# Altium schematic sheet SAM3X_MCU2.SchDoc
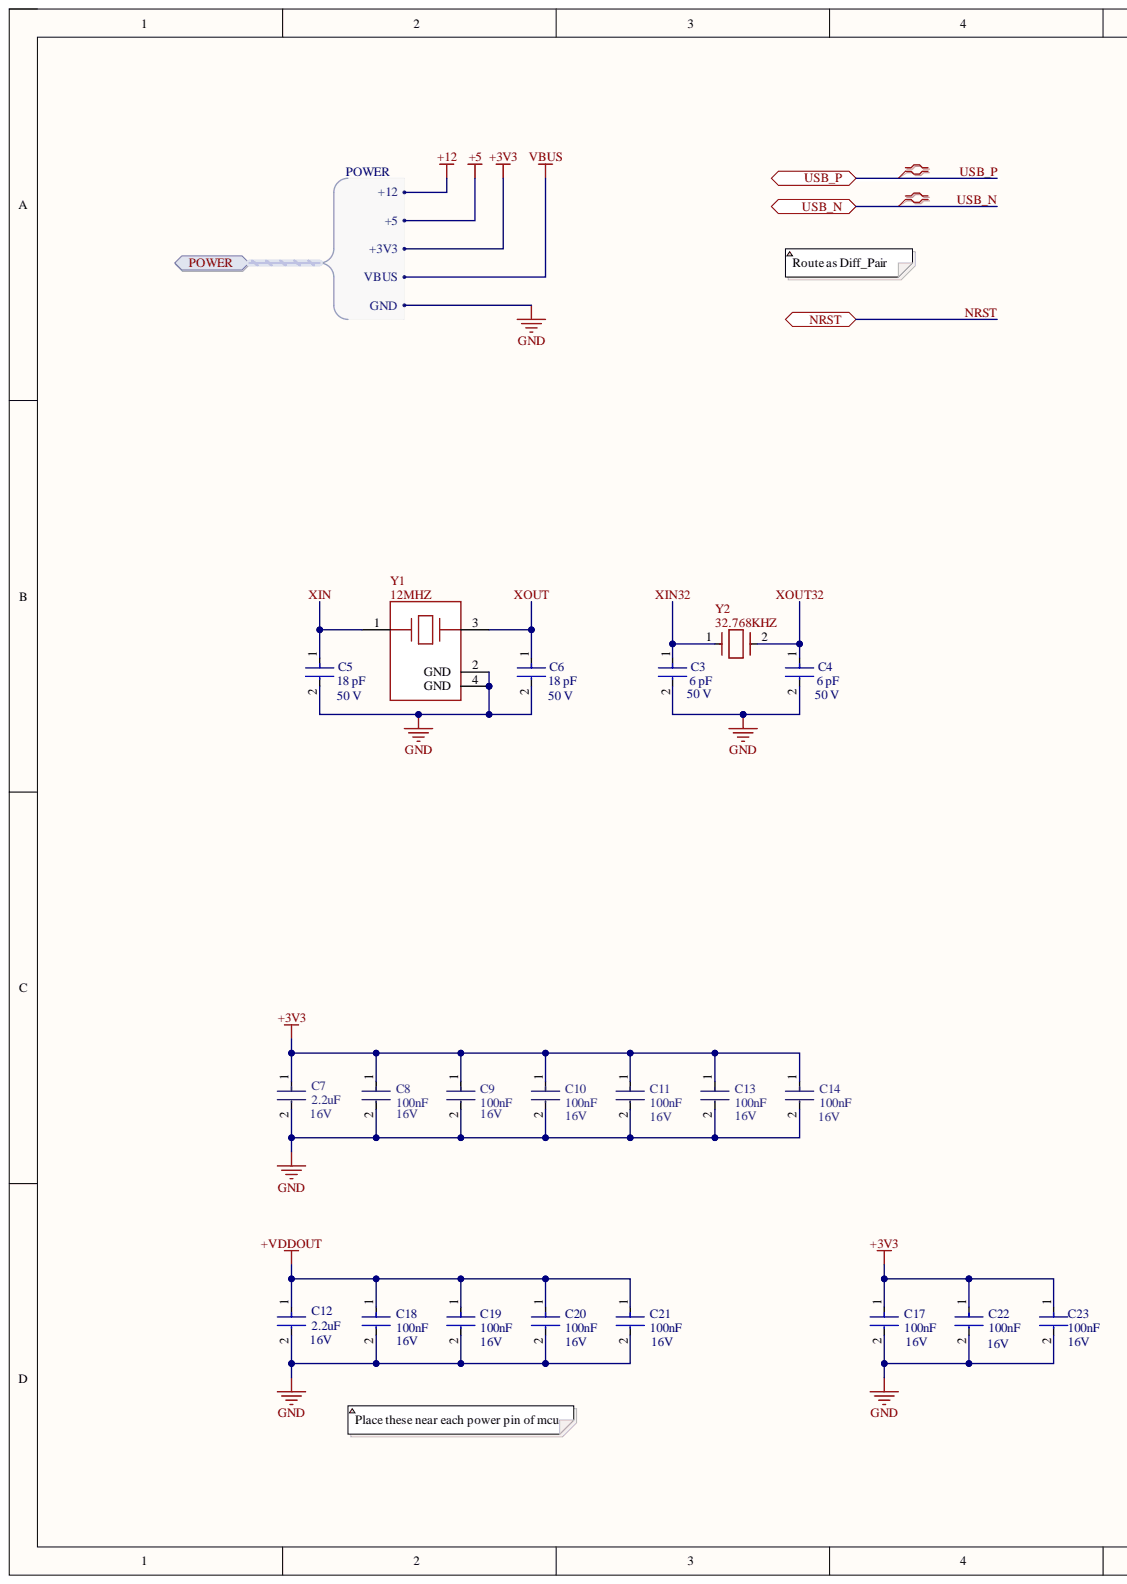
[diagram p0: SAM3X_MCU2.SchDoc, left]
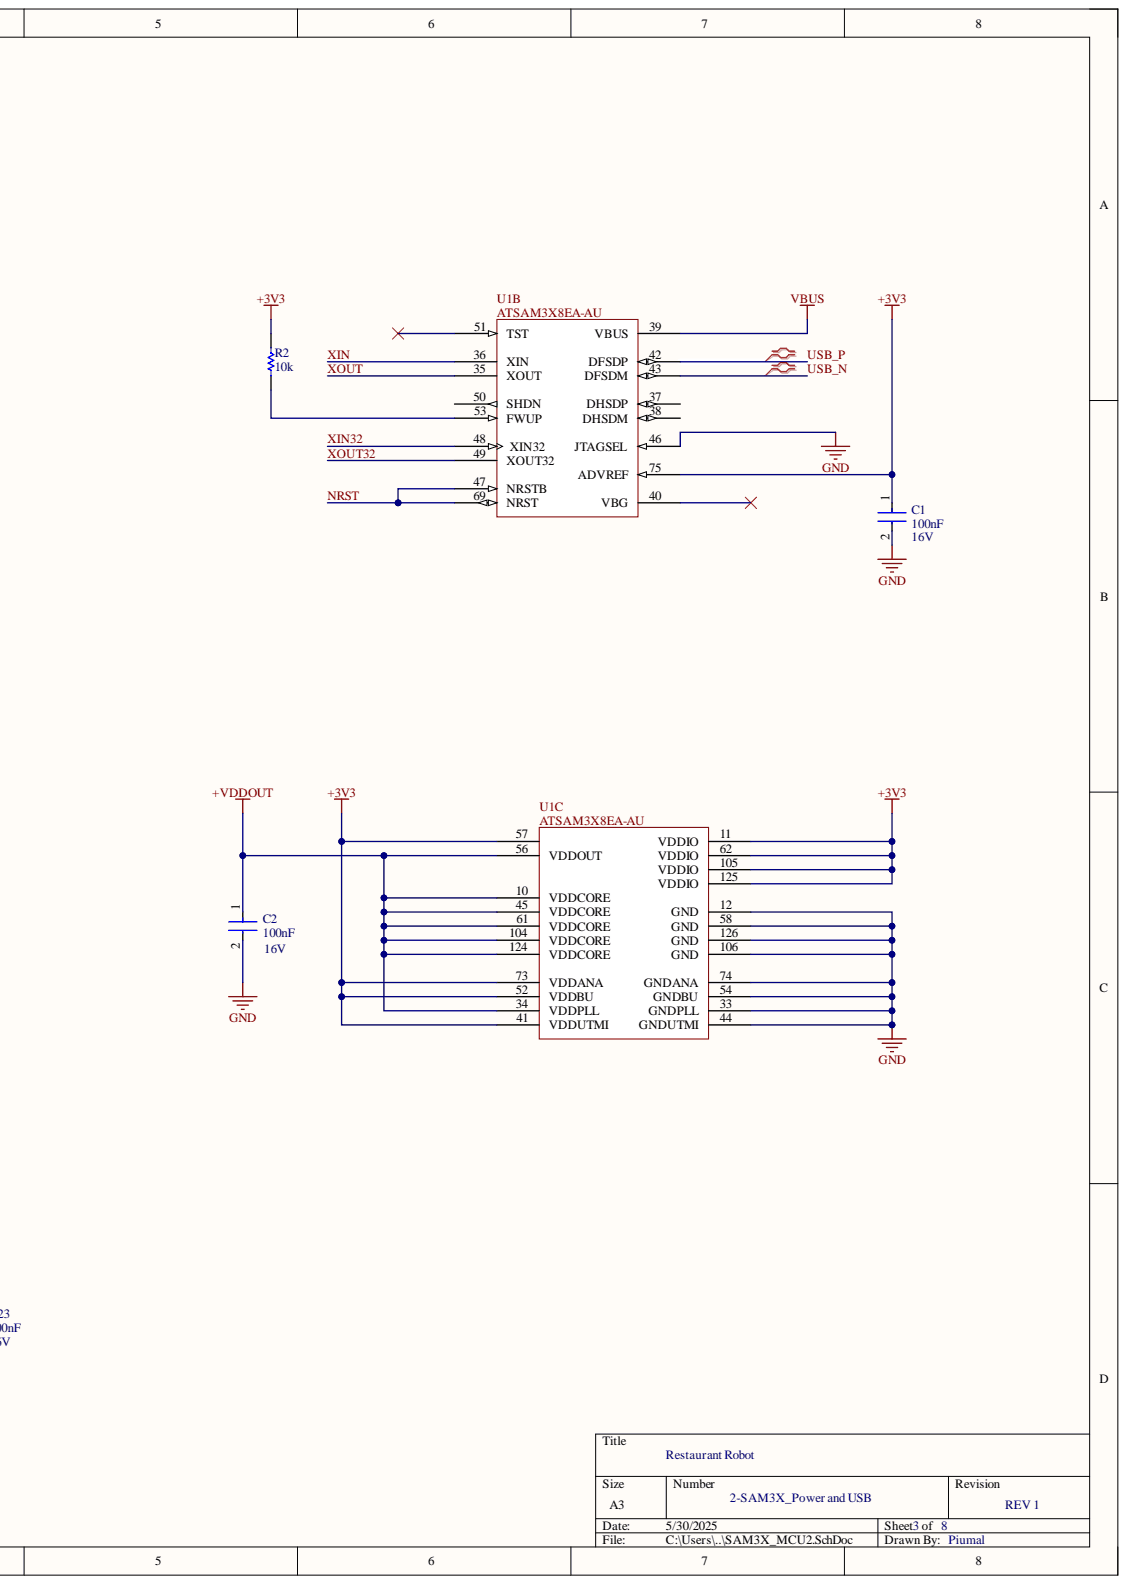
[diagram p1: SAM3X_MCU2.SchDoc, right]

## Parts
C1  Capacitance: 100nF  Voltage Rating: 16V  [FP-CL21-IPC_A]  (hidden comment: CL21B104KOANNNC)
C2  Capacitance: 100nF  Voltage Rating: 16V  [FP-CL21-IPC_A]  (hidden comment: CL21B104KOANNNC)
C3  Capacitance: 6 pF  Voltage Rating: 50 V  [FP-C0805C-DN-MFG]  (hidden comment: C0805C120J5GACTU)
C4  Capacitance: 6 pF  Voltage Rating: 50 V  [FP-C0805C-DN-MFG]  (hidden comment: C0805C120J5GACTU)
C5  C0805C120J5GACTU  [FP-C0805C-DN-MFG]  (value not drawn)
C6  Capacitance: 18 pF  Voltage Rating: 50 V  [FP-C0805C-DN-MFG]  (hidden comment: C0805C120J5GACTU)
C7  Capacitance: 2.2uF  Voltage Rating: 16V  [FP-CL21-IPC_A]  (hidden comment: CL21A225KOFNNNG)
C8  Capacitance: 100nF  Voltage Rating: 16V  [FP-CL21-IPC_A]  (hidden comment: CL21B104KOANNNC)
C9  Capacitance: 100nF  Voltage Rating: 16V  [FP-CL21-IPC_A]  (hidden comment: CL21B104KOANNNC)
C10  Capacitance: 100nF  Voltage Rating: 16V  [FP-CL21-IPC_A]  (hidden comment: CL21B104KOANNNC)
C11  Capacitance: 100nF  Voltage Rating: 16V  [FP-CL21-IPC_A]  (hidden comment: CL21B104KOANNNC)
C12  Capacitance: 2.2uF  Voltage Rating: 16V  [FP-CL21-IPC_A]  (hidden comment: CL21A225KOFNNNG)
C13  Capacitance: 100nF  Voltage Rating: 16V  [FP-CL21-IPC_A]  (hidden comment: CL21B104KOANNNC)
C14  Capacitance: 100nF  Voltage Rating: 16V  [FP-CL21-IPC_A]  (hidden comment: CL21B104KOANNNC)
C17  Capacitance: 100nF  Voltage Rating: 16V  [FP-CL21-IPC_A]  (hidden comment: CL21B104KOANNNC)
C18  Capacitance: 100nF  Voltage Rating: 16V  [FP-CL21-IPC_A]  (hidden comment: CL21B104KOANNNC)
C19  Capacitance: 100nF  Voltage Rating: 16V  [FP-CL21-IPC_A]  (hidden comment: CL21B104KOANNNC)
C20  Capacitance: 100nF  Voltage Rating: 16V  [FP-CL21-IPC_A]  (hidden comment: CL21B104KOANNNC)
C21  Capacitance: 100nF  Voltage Rating: 16V  [FP-CL21-IPC_A]  (hidden comment: CL21B104KOANNNC)
C22  Capacitance: 100nF  Voltage Rating: 16V  [FP-CL21-IPC_A]  (hidden comment: CL21B104KOANNNC)
C23  value 100nF  Voltage Rating: 16V  [FP-CL21-IPC_A]  (hidden comment: CL21B104KOANNNC)
R2  Resistance: 10k  [RESC2013X60X35ML10T20]  (hidden comment: RC0805JR-0710KL)
U1  ATSAM3X8EA-AU  [LQFP144_M]  (parts B,C)
Y1  12MHZ  [FP-ABM8G-MFG]
Y2  32.768KHZ  [FP-NX3215SA-MFG]

## Ports
NRST, POWER, POWER.+3V3, POWER.+5, POWER.+12, POWER.GND, POWER.VBUS, USB_N, USB_P

## Nets
+VDDOUT: C2.1 C12.1 C18.1 C19.1 C20.1 C21.1 U1.10(VDDCORE) U1.34(VDDPLL) U1.45(VDDCORE) U1.56(VDDOUT) U1.61(VDDCORE) U1.104(VDDCORE) U1.124(VDDCORE)
+3V3: C1.1 C7.1 C8.1 C9.1 C10.1 C11.1 C13.1 C14.1 C17.1 C22.1 C23.1 R2.2 U1.11(VDDIO) U1.41(VDDUTMI) U1.52(VDDBU) U1.57 U1.62(VDDIO) U1.73(VDDANA) U1.75(ADVREF) U1.105(VDDIO) U1.125(VDDIO) ; port POWER
GND: C1.2 C2.2 C3.2 C4.2 C5.2 C6.2 C7.2 C8.2 C9.2 C10.2 C11.2 C12.2 C13.2 C14.2 C17.2 C18.2 C19.2 C20.2 C21.2 C22.2 C23.2 U1.12(GND) U1.33(GNDPLL) U1.44(GNDUTMI) U1.46(JTAGSEL) U1.54(GNDBU) U1.58(GND) U1.74(GNDANA) U1.106(GND) U1.126(GND) Y1.2(GND) Y1.4(GND) ; port POWER
NRST: U1.47(NRSTB) U1.69(NRST) ; port NRST
USB_N: U1.43(DFSDM) ; port USB_N
USB_P: U1.42(DFSDP) ; port USB_P
VBUS: U1.39(VBUS) ; port POWER
XIN: C5.1 U1.36(XIN) Y1.1
XIN32: C3.1 U1.48(XIN32) Y2.1
XOUT: C6.1 U1.35(XOUT) Y1.3
XOUT32: C4.1 U1.49(XOUT32) Y2.2
NetR2_1: R2.1 U1.53(FWUP)
NetU1_37: U1.37(DHSDP)
NetU1_38: U1.38(DHSDM)
NetU1_40: U1.40(VBG)
NetU1_50: U1.50(SHDN)
NetU1_51: U1.51(TST)
+5: ; port POWER
+12: ; port POWER

## Library data of the parts
C1 C2 C8-C11 C13 C14 C17-C23 = CMP-13271-003679-1: CL21 Series 0805 100nF 16V ±10% Tolerance X7R Multilayer Ceramic Chip Capacitor; Case Code (Imperial): 0805; Case Code (Metric): 2012; Case/Package: 0805; Component Kind: Standard; Depth: 1.25mm; Dielectric: X7R; Height: 0.65mm; Lead Free: Lead Free; Length: 2mm; Library Name: Altium Content Vault; Max Operating Temperature: 125°C; Min Operating Temperature: -55°C; Mount: Surface Mount; Package Quantity: 1; Packaging: Tape and Reel; Radiation Hardening: No; Thickness: 0.03inch; Tolerance: 10%; Voltage Rating (DC): 16V; Width: 0.049inch
C3 C4 C6 = CMP-2007-00441-2: CAP CER 12PF 50V C0G/NP0 0805; Manufacturer: KEMET; Material: Ceramic; Temperature Characteristic: C0G; Temperature Coefficient: 30ppm/°C; Tolerance: 0.05; Automotive: No; Category: Cap; Component Kind: Standard; ComponentLink2Description: Datasheet; ComponentLink2URL: https://content.kemet.com/datasheets/KEM_C1003_C0G_SMD.pdf; Device Class L1: Passive Components; Device Class L2: Capacitors; Device Class L3: Ceramic Capacitors; Digikey Description: CAP CER 12PF 50V C0G/NP0 0805; Height: 0.88mm; Ipc Land Pattern Name: CAPC200125X78; Lead Free: Yes; Library Name: Altium Content Vault; Manufacturer Part Number: C0805C120J5GACTU; Mouser Description: Multilayer Ceramic Capacitors MLCC - SMD/SMT 50volts 12pF C0G 5%; Octopart: https://octopart.com/c0805c120j5gactu-kemet-126518; Package: C0805C-DN; RoHS: TRUE; Temperature: -55°C to +125°C; Temperature Range High: +125°C; Temperature Range Low: -55°C; Value: 12 pF
C5 = CMP-2007-00441-2: CAP CER 12PF 50V C0G/NP0 0805; Manufacturer: KEMET; Capacitance: 18 pF; Material: Ceramic; Temperature Characteristic: C0G; Temperature Coefficient: 30ppm/°C; Tolerance: 0.05; Automotive: No; Category: Cap; Component Kind: Standard; ComponentLink2Description: Datasheet; ComponentLink2URL: https://content.kemet.com/datasheets/KEM_C1003_C0G_SMD.pdf; Device Class L1: Passive Components; Device Class L2: Capacitors; Device Class L3: Ceramic Capacitors; Digikey Description: CAP CER 12PF 50V C0G/NP0 0805; Height: 0.88mm; Ipc Land Pattern Name: CAPC200125X78; Lead Free: Yes; Library Name: Altium Content Vault; Manufacturer Part Number: C0805C120J5GACTU; Mouser Description: Multilayer Ceramic Capacitors MLCC - SMD/SMT 50volts 12pF C0G 5%; Octopart: https://octopart.com/c0805c120j5gactu-kemet-126518; Package: C0805C-DN; RoHS: TRUE; Temperature: -55°C to +125°C; Temperature Range High: +125°C; Temperature Range Low: -55°C; Value: 12 pF; Voltage Rating: 50 V
C7 C12 = CMP-13271-001347-1: CL21 Series 0805 2.2uF 16V ±10% Tolerance X5R Multilayer Ceramic Chip Capacitor; Case Code (Imperial): 0805; Case Code (Metric): 2012; Case/Package: 0805; Component Kind: Standard; Length: 0.079inch; Library Name: Altium Content Vault; Max Operating Temperature: 85°C; Min Operating Temperature: -55°C; Mount: Surface Mount; Package Quantity: 3000; Packaging: Tape and Reel; Thickness: 0.053inch; Tolerance: 10%; Width: 0.049inch
R2 = CMP-2000-05025-1: Chip Resistor, 10 KOhm, +/- 5%, 0.125 W, -55 to 155 degC, 0805 (2012 Metric), RoHS, Tape and Reel; Manufacturer: Yageo; Power Rating: 250mW; Product Range: RCSeries; Resistor Case Style: 0805[2012Metric]; Resistor Element Type: ThickFilm; SVHC: ToBeAdvised; Temperature Coefficient: ±100ppm/degC; Voltage Rating: 150V; Automotive Qualification Standard: -; Component Kind: Standard; Datasheet URL: http://www.yageo.ru/pdf/RC0805.pdf; Datasheet Version: REV. 2, 09/2004; Library Name: Altium Content Vault; Manufacturer URL: http://www.yageo.com/; MSL: MSL1-Unlimited; Package Description: 2-Pin Surface Mounted Device, Body 2 x 1.25 mm; Package Reference: 805; Packaging: CutTape; Resistance Tolerance: ±5%
U1 = CMP-0076-00188-1: SAM3X ARM Cortex-M3 Flash-based Microcontroller, 512 KB Flash, 96 KB SRAM, 103 User I/Os, 1.62 to 3.6 V, -40 to 85 degC, 144-Pin LQFP, RoHS; Manufacturer: Atmel; # of Touch Channels: 32; ADC Channels: 16; ADC Resolution: 12 bits; CAN: 2; Flash: 512 Kbytes; Max I/O Pins: 103; Max. Operating Freq.: 84 MHz; SPI: 4; SRAM: 96 Kbytes; UART: 5; Component Kind: Standard; ComponentLink1Description: Manufacturer URL; ComponentLink1URL: http://www.atmel.com/; ComponentLink2Description: Datasheet; ComponentLink2URL: http://www.atmel.com/Images/doc11057.pdf; ComponentLink3Description: Package Specification; ComponentLink3URL: http://www.atmel.com/Images/doc11057.pdf; DAC Channels: 2; DatasheetVersion: 11057B; Library Name: Altium Content Vault; Mounting Technology: Surface Mount; Operating Voltage: 1.62 to 3.6 V; PackageDescription: 100-Pin Quad Flat Package, Body 20 x 20 mm, Pitch 0.5 mm; PackageReference: LQFP-144; PackageVersion: 11057B; PartNumber: ATSAM3X8EA-AU; RoHS: TRUE; Temp. Range: -40 to 85 degC
Y1 = CMP-2000-05039-3: Crystal 12MHz ±20ppm (Tol) ±30ppm (Stability) 18pF FUND 120Ω 4-Pin SMD T/R; ESR (Equivalent Series Resistance): 120R; Case/Package: CSMD; Component Kind: Standard; Depth: 2.5mm; Frequency: 12MHz; Frequency Stability: 30ppm; Frequency Tolerance: 20ppm; Height: 1mm; Lead Free: Lead Free; Length: 3.2mm; Library Name: Altium Content Vault; Load Capacitance: 18pF; Max Operating Temperature: 85°C; Min Operating Temperature: -40°C; Mount: Surface Mount; Number of Pins: 4; Operating Frequency: 12 MHz; Package Quantity: 1000; Packaging: Tape and Reel; Radiation Hardening: No; Series: ABM8G; Series Resistance: 120R; Termination: SMD/SMT; Tolerance: 20ppm; Width: 2.5mm
Y2 = CMP-00536-22488149-1: NX3215SA-32.768K-STD-MUA-14 Parallel - Fundamental Quartz Crystal, 0.032768MHz Nom; ESR (Equivalent Series Resistance): 70kΩ; Frequency Tolerance: 0.002%; Load Capacitance: 6pF; Case/Package: SMD/SMT; Component Kind: Standard; Frequency: 32.768kHz; Height: 889µm; Length: 3.2004mm; Library Name: Altium Content Vault; Max Operating Temperature: 85°C; Min Operating Temperature: -40°C; Mount: Surface Mount; NexarPartId: 22488149; Number of Pins: 2; Packaging: Tape & Reel (TR); RoHS: Compliant; Width: 1.4986mm
